# Altium schematic sheet Load.SchDoc(Load)
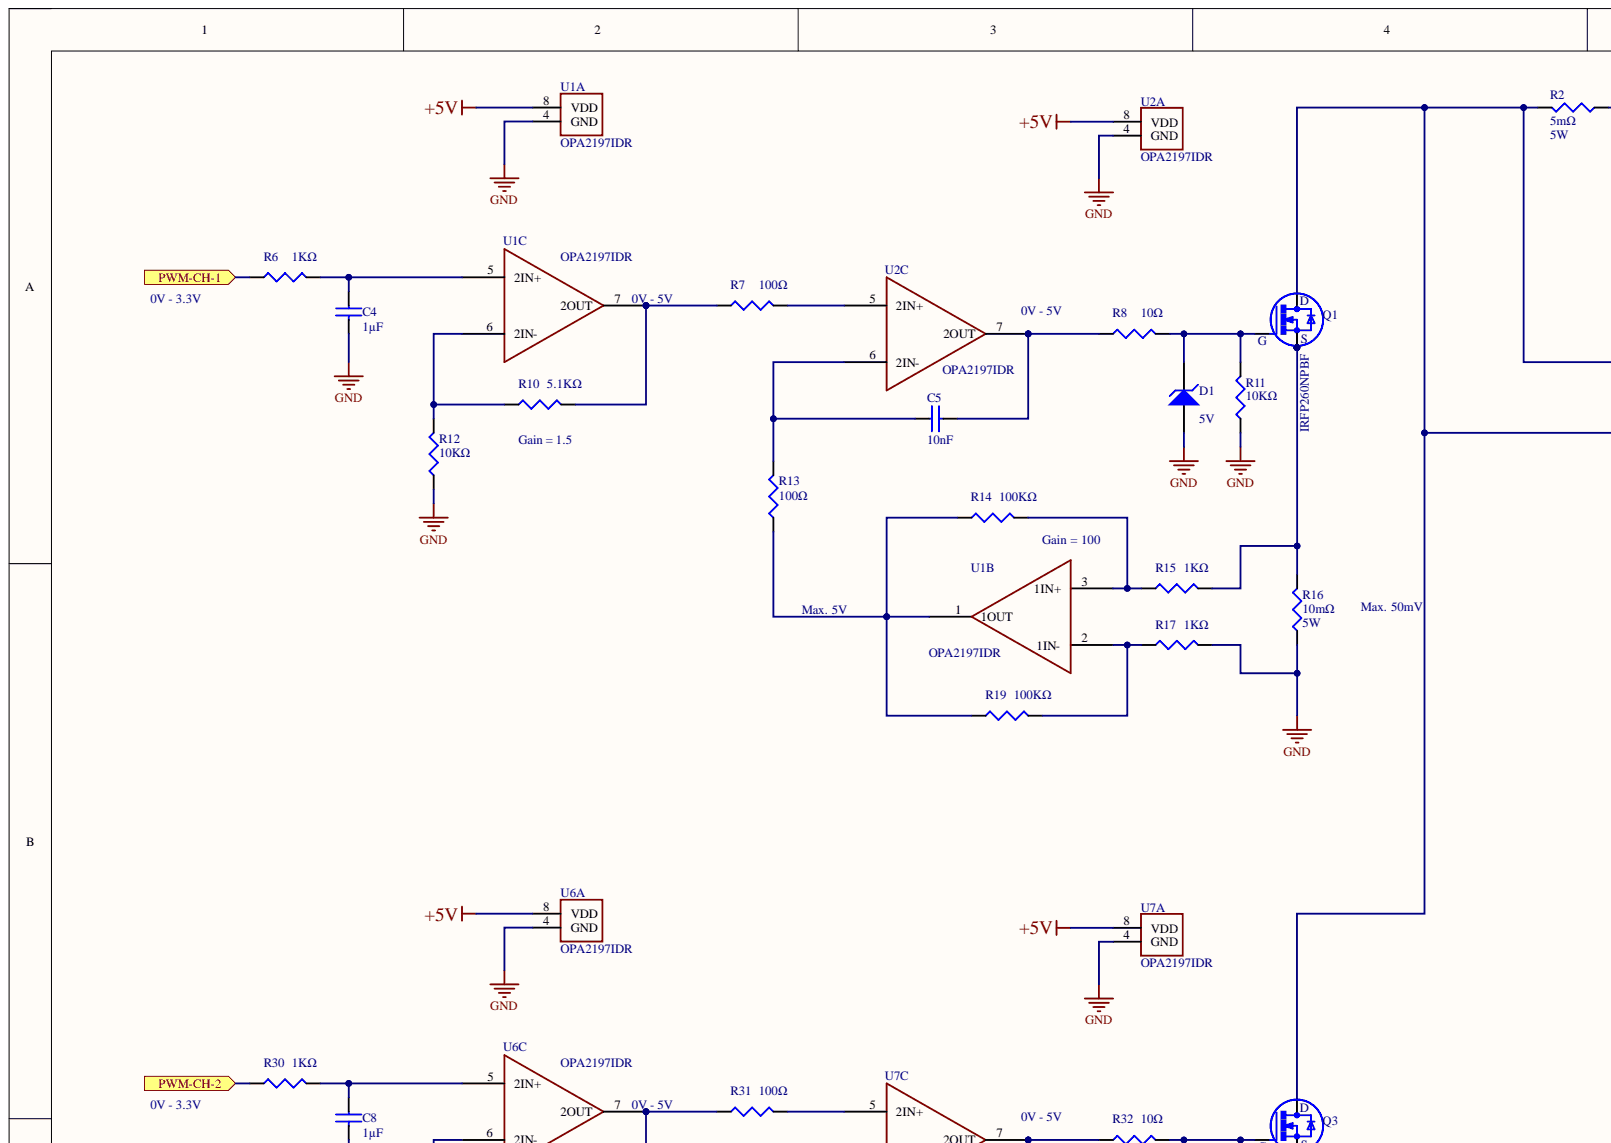
[diagram p0: Load.SchDoc, top left]
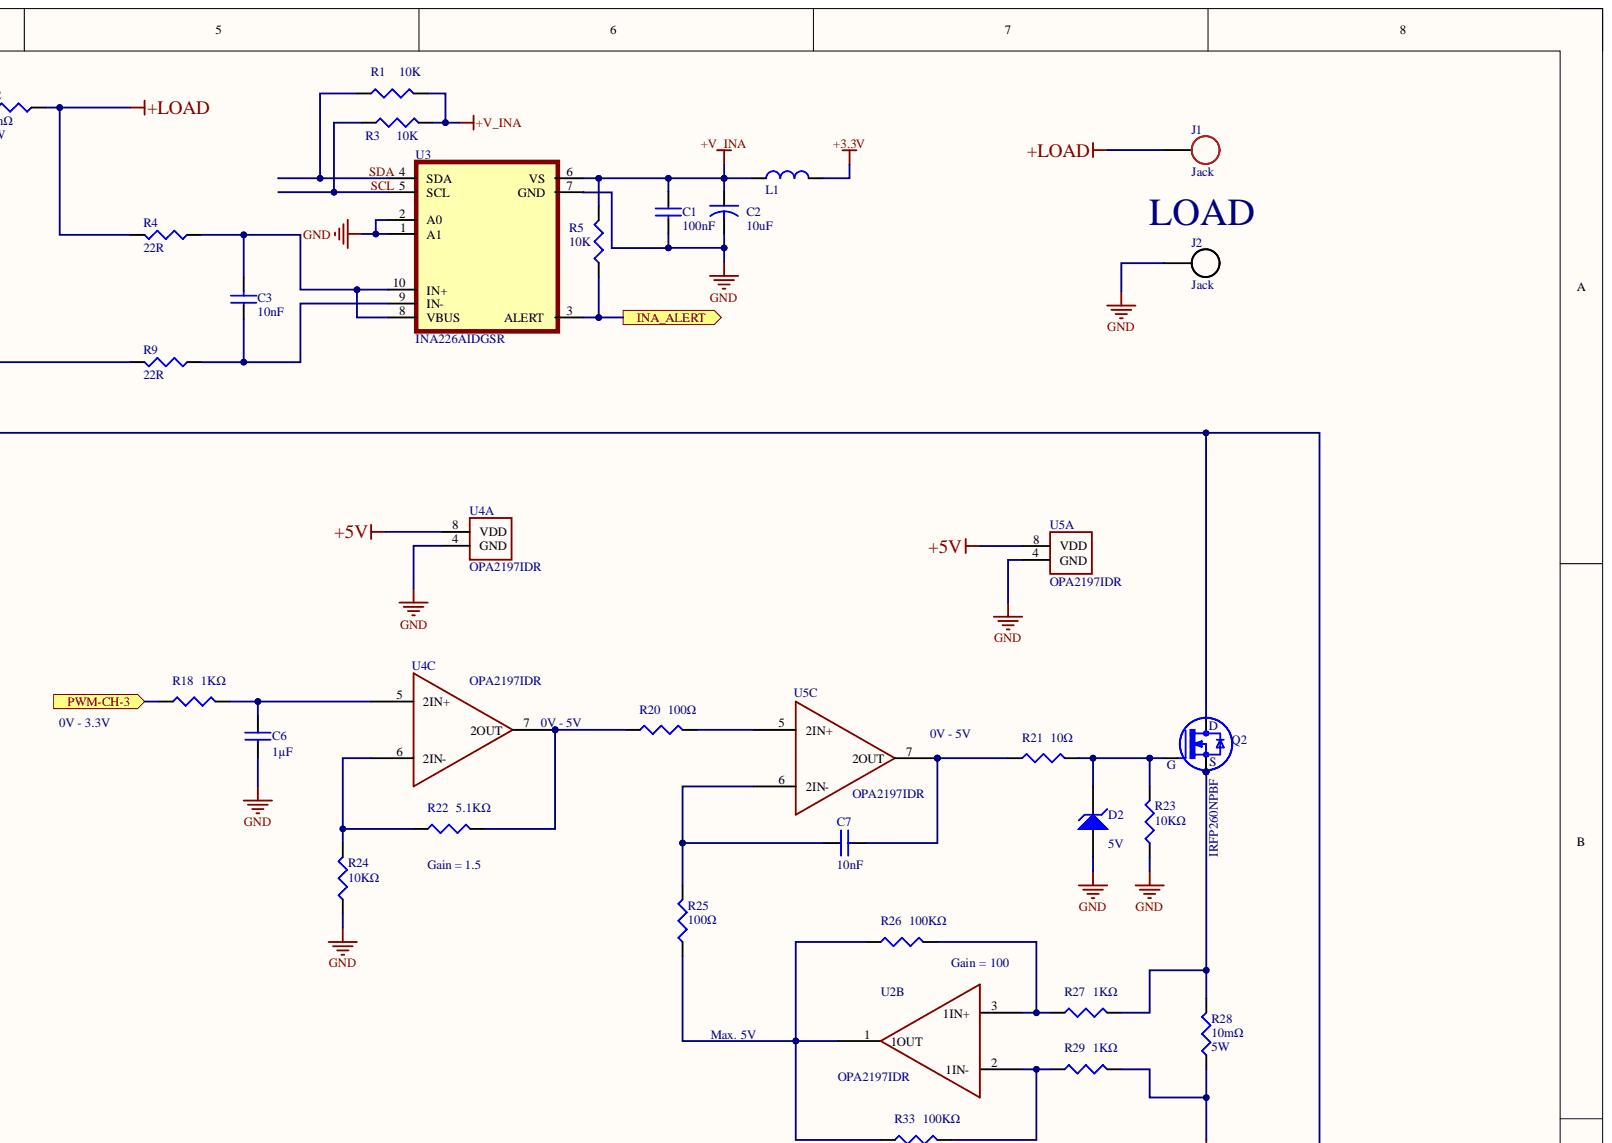
[diagram p1: Load.SchDoc, top right]
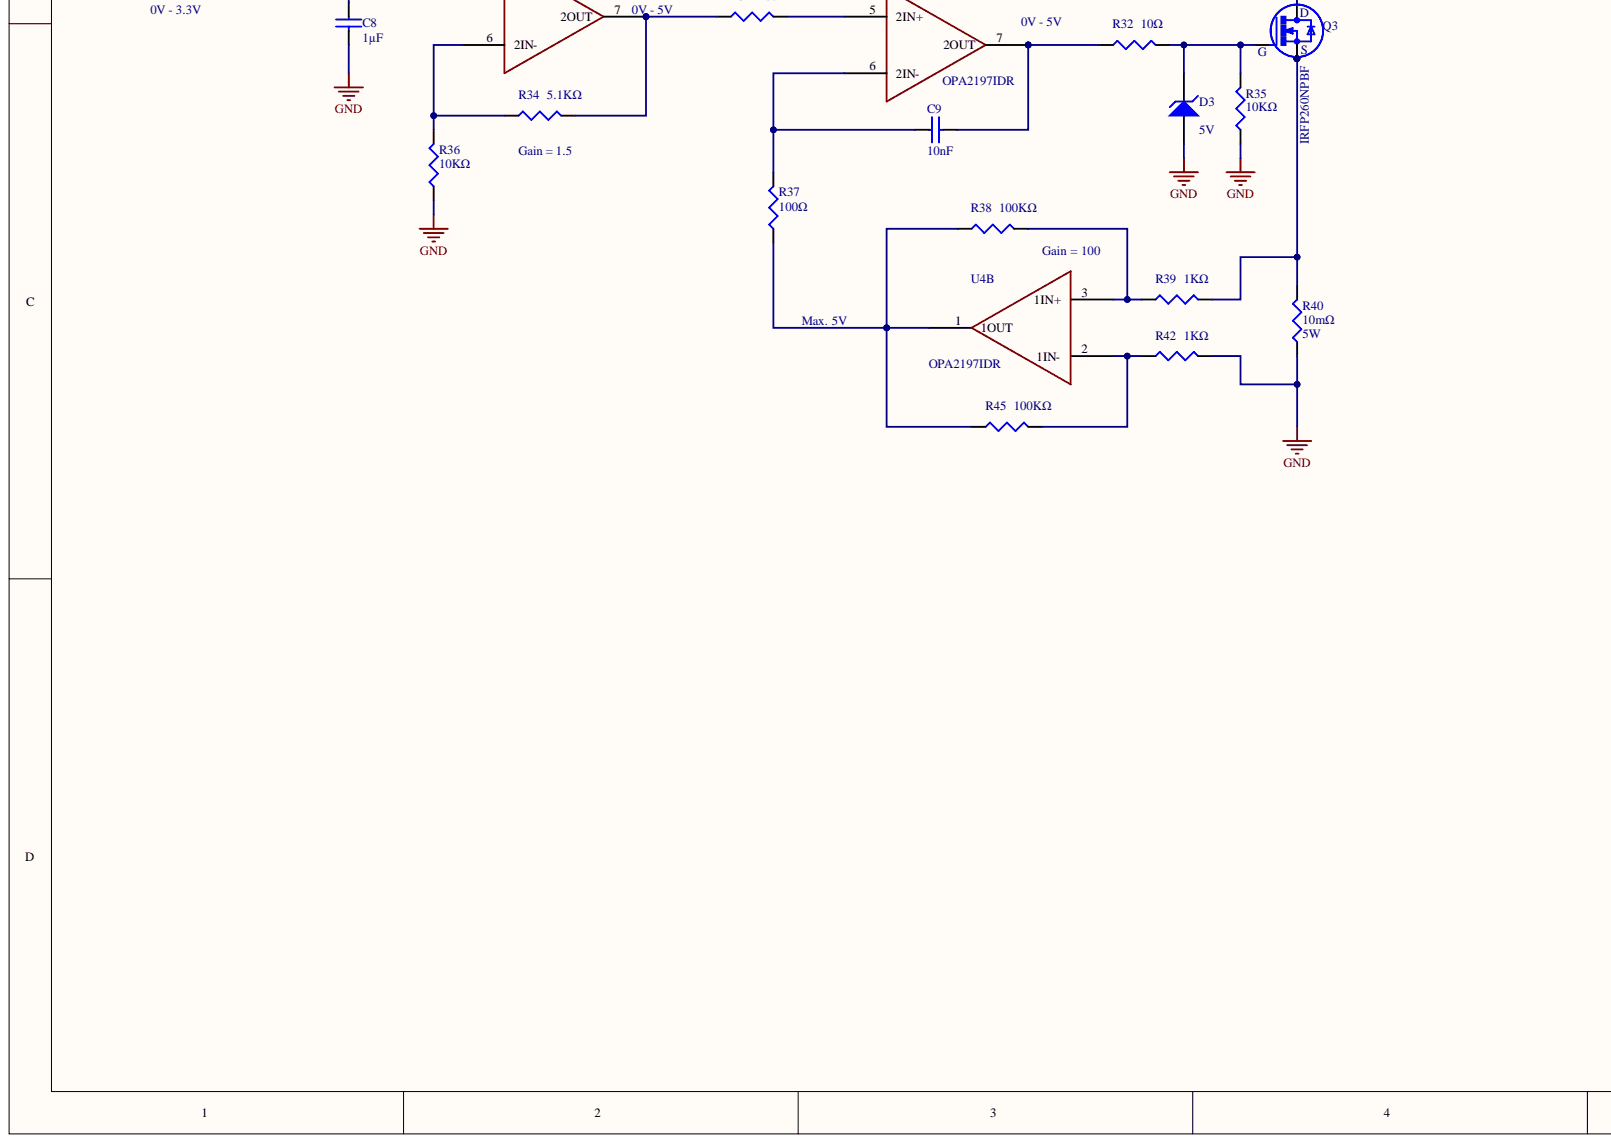
[diagram p2: Load.SchDoc, bottom left]
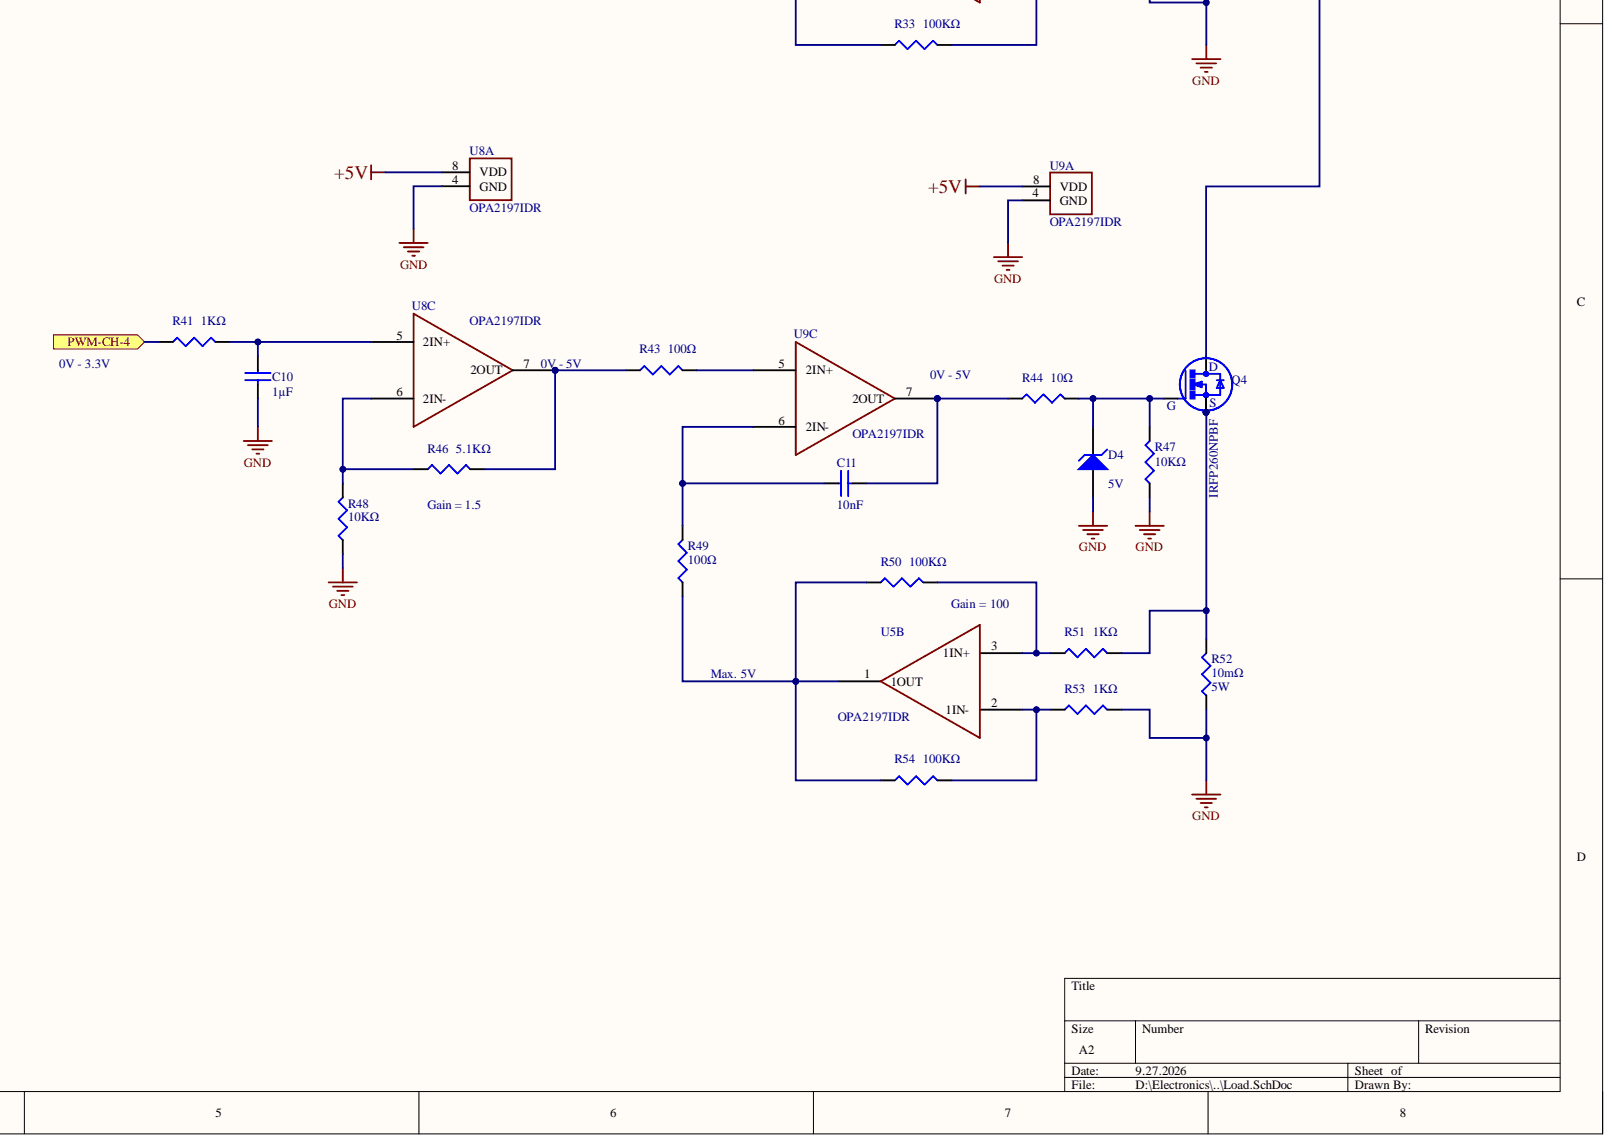
[diagram p3: Load.SchDoc, bottom right]

## Parts
C1  value 100nF  [0805 SMD Ceramic Capacitor]  (hidden comment: C0805C104)
C2  value 10uF  [A Case]  (hidden comment: T491A106)
C3  value 10nF  [0805 SMD Ceramic Capacitor]  (hidden comment: C0805C103)
C4  value 1µF  [0805 SMD Ceramic Capacitor]  (hidden comment: C0805C103)
C5  value 10nF  [0805 SMD Ceramic Capacitor]  (hidden comment: C0805C103)
C6  value 1µF  [0805 SMD Ceramic Capacitor]  (hidden comment: C0805C103)
C7  value 10nF  [0805 SMD Ceramic Capacitor]  (hidden comment: C0805C103)
C8  value 1µF  [0805 SMD Ceramic Capacitor]  (hidden comment: C0805C103)
C9  value 10nF  [0805 SMD Ceramic Capacitor]  (hidden comment: C0805C103)
C10  value 1µF  [0805 SMD Ceramic Capacitor]  (hidden comment: C0805C103)
C11  value 10nF  [0805 SMD Ceramic Capacitor]  (hidden comment: C0805C103)
D1  Voltage: 5V  [SOD80C]  (hidden comment: ZMM55C15-HT)
D2  Voltage: 5V  [SOD80C]  (hidden comment: ZMM55C15-HT)
D3  Voltage: 5V  [SOD80C]  (hidden comment: ZMM55C15-HT)
D4  Voltage: 5V  [SOD80C]  (hidden comment: ZMM55C15-HT)
J1  value Jack  [Red_Banana_Jack]  (hidden comment: RED_Banana_Jack)
J2  value Jack  [Black_Banana_Jack]  (hidden comment: BLACK_Banana_Jack)
L1  0805Ferrite  [0805 Inductor]  (value not drawn)
Q1  IRFP260NPBF  [TO-247AC_H]
Q2  IRFP260NPBF  [TO-247AC_H]
Q3  IRFP260NPBF  [TO-247AC_H]
Q4  IRFP260NPBF  [TO-247AC_H]
R1  value 10K  [0805 Resistor]  (hidden comment: 0805_10K)
R2  value 5mΩ  Power: 5W  [2512 RESISTOR]  (hidden comment: 2512_1mR%1)
R3  value 10K  [0805 Resistor]  (hidden comment: 0805_10K)
R4  value 22R  [0805 Resistor]  (hidden comment: 0805_22R%1)
R5  value 10K  [0805 Resistor]  (hidden comment: 0805_10K)
R6  value 1KΩ  [0805 Resistor]  (hidden comment: 0805)
R7  value 100Ω  [0805 Resistor]  (hidden comment: 0805)
R8  value 10Ω  [0805 Resistor]  (hidden comment: 0805)
R9  value 22R  [0805 Resistor]  (hidden comment: 0805_22R%1)
R10  value 5.1KΩ  [0805 Resistor]  (hidden comment: 0805)
R11  value 10KΩ  [0805 Resistor]  (hidden comment: 0805)
R12  value 10KΩ  [0805 Resistor]  (hidden comment: 0805)
R13  value 100Ω  [0805 Resistor]  (hidden comment: 0805)
R14  value 100KΩ  [0805 Resistor]  (hidden comment: 0805)
R15  value 1KΩ  [0805 Resistor]  (hidden comment: 0805)
R16  value 10mΩ  Power: 5W  [2512 RESISTOR]  (hidden comment: 2512_1mR%1)
R17  value 1KΩ  [0805 Resistor]  (hidden comment: 0805)
R18  value 1KΩ  [0805 Resistor]  (hidden comment: 0805)
R19  value 100KΩ  [0805 Resistor]  (hidden comment: 0805)
R20  value 100Ω  [0805 Resistor]  (hidden comment: 0805)
R21  value 10Ω  [0805 Resistor]  (hidden comment: 0805)
R22  value 5.1KΩ  [0805 Resistor]  (hidden comment: 0805)
R23  value 10KΩ  [0805 Resistor]  (hidden comment: 0805)
R24  value 10KΩ  [0805 Resistor]  (hidden comment: 0805)
R25  value 100Ω  [0805 Resistor]  (hidden comment: 0805)
R26  value 100KΩ  [0805 Resistor]  (hidden comment: 0805)
R27  value 1KΩ  [0805 Resistor]  (hidden comment: 0805)
R28  value 10mΩ  Power: 5W  [2512 RESISTOR]  (hidden comment: 2512_1mR%1)
R29  value 1KΩ  [0805 Resistor]  (hidden comment: 0805)
R30  value 1KΩ  [0805 Resistor]  (hidden comment: 0805)
R31  value 100Ω  [0805 Resistor]  (hidden comment: 0805)
R32  value 10Ω  [0805 Resistor]  (hidden comment: 0805)
R33  value 100KΩ  [0805 Resistor]  (hidden comment: 0805)
R34  value 5.1KΩ  [0805 Resistor]  (hidden comment: 0805)
R35  value 10KΩ  [0805 Resistor]  (hidden comment: 0805)
R36  value 10KΩ  [0805 Resistor]  (hidden comment: 0805)
R37  value 100Ω  [0805 Resistor]  (hidden comment: 0805)
R38  value 100KΩ  [0805 Resistor]  (hidden comment: 0805)
R39  value 1KΩ  [0805 Resistor]  (hidden comment: 0805)
R40  value 10mΩ  Power: 5W  [2512 RESISTOR]  (hidden comment: 2512_1mR%1)
R41  value 1KΩ  [0805 Resistor]  (hidden comment: 0805)
R42  value 1KΩ  [0805 Resistor]  (hidden comment: 0805)
R43  value 100Ω  [0805 Resistor]  (hidden comment: 0805)
R44  value 10Ω  [0805 Resistor]  (hidden comment: 0805)
R45  value 100KΩ  [0805 Resistor]  (hidden comment: 0805)
R46  value 5.1KΩ  [0805 Resistor]  (hidden comment: 0805)
R47  value 10KΩ  [0805 Resistor]  (hidden comment: 0805)
R48  value 10KΩ  [0805 Resistor]  (hidden comment: 0805)
R49  value 100Ω  [0805 Resistor]  (hidden comment: 0805)
R50  value 100KΩ  [0805 Resistor]  (hidden comment: 0805)
R51  value 1KΩ  [0805 Resistor]  (hidden comment: 0805)
R52  value 10mΩ  Power: 5W  [2512 RESISTOR]  (hidden comment: 2512_1mR%1)
R53  value 1KΩ  [0805 Resistor]  (hidden comment: 0805)
R54  value 100KΩ  [0805 Resistor]  (hidden comment: 0805)
U1  OPA2197IDR  [SO8]  (parts A,B,C)
U2  OPA2197IDR  [SO8]  (parts A,B,C)
U3  INA226AIDGSR  [SOP50P490X110-10N]
U4  OPA2197IDR  [SO8]  (parts A,B,C)
U5  OPA2197IDR  [SO8]  (parts A,B,C)
U6  OPA2197IDR  [SO8]  (parts A,C)
U7  OPA2197IDR  [SO8]  (parts A,C)
U8  OPA2197IDR  [SO8]  (parts A,C)
U9  OPA2197IDR  [SO8]  (parts A,C)

## Ports
INA_ALERT, PWM-CH-1, PWM-CH-2, PWM-CH-3, PWM-CH-4

## Nets
+3.3V: L1.2
+5V: U1.8(VDD) U2.8(VDD) U4.8(VDD) U5.8(VDD) U6.8(VDD) U7.8(VDD) U8.8(VDD) U9.8(VDD)
+LOAD: J1.1 R2.1 R4.2
+V_INA: C1.1 C2.1 L1.1 R1.2 R3.2 R5.2 U3.6(VS)
GND: C1.2 C2.2 C4.2 C6.2 C8.2 C10.2 D1.2 D2.2 D3.2 D4.2 J2.1 R11.1 R12.1 R16.1 R17.2 R23.1 R24.1 R28.1 R29.2 R35.1 R36.1 R40.1 R42.2 R47.1 R48.1 R52.1 R53.2 U1.4(GND) U2.4(GND) U3.1(A1) U3.2(A0) U3.7(GND) U4.4(GND) U5.4(GND) U6.4(GND) U7.4(GND) U8.4(GND) U9.4(GND)
INA_ALERT: R5.1 U3.3(ALERT) ; port INA_ALERT
PWM-CH-1: R6.1 ; port PWM-CH-1
PWM-CH-2: R30.1 ; port PWM-CH-2
PWM-CH-3: R18.1 ; port PWM-CH-3
PWM-CH-4: R41.1 ; port PWM-CH-4
SDA: R1.1 U3.4(SDA)
NetC3_1: C3.1 R9.1 U3.9(IN-)
NetC3_2: C3.2 R4.1 U3.8(VBUS) U3.10(IN+)
NetC4_1: C4.1 R6.2 U1.5(2IN+)
NetC5_1: C5.1 R13.2 U2.6(2IN-)
NetC5_2: C5.2 R8.1 U2.7(2OUT)
NetC6_1: C6.1 R18.2 U4.5(2IN+)
NetC7_1: C7.1 R25.2 U5.6(2IN-)
NetC7_2: C7.2 R21.1 U5.7(2OUT)
NetC8_1: C8.1 R30.2 U6.5(2IN+)
NetC9_1: C9.1 R37.2 U7.6(2IN-)
NetC9_2: C9.2 R32.1 U7.7(2OUT)
NetC10_1: C10.1 R41.2 U8.5(2IN+)
NetC11_1: C11.1 R49.2 U9.6(2IN-)
NetC11_2: C11.2 R44.1 U9.7(2OUT)
NetD1_1: D1.1 Q1.1 R8.2 R11.2
NetD2_1: D2.1 Q2.1 R21.2 R23.2
NetD3_1: D3.1 Q3.1 R32.2 R35.2
NetD4_1: D4.1 Q4.1 R44.2 R47.2
NetQ1_1: Q1.1 Q1.2 Q1.3 R15.2 R16.2
NetQ1_2: Q1.2 Q2.2 Q3.2 Q4.2 R2.2 R9.2
NetQ2_1: Q2.1 Q2.2 Q2.3 R27.2 R28.2
NetQ3_1: Q3.1 Q3.2 Q3.3 R39.2 R40.2
NetQ4_1: Q4.1 Q4.2 Q4.3 R51.2 R52.2
NetR3_1: R3.1 U3.5(SCL)
NetR7_1: R7.1 R10.2 U1.7(2OUT)
NetR7_2: R7.2 U2.5(2IN+)
NetR10_1: R10.1 R12.2 U1.6(2IN-)
NetR13_1: R13.1 R14.1 R19.1 U1.1(1OUT)
NetR14_2: R14.2 R15.1 U1.3(1IN+)
NetR17_1: R17.1 R19.2 U1.2(1IN-)
NetR20_1: R20.1 R22.2 U4.7(2OUT)
NetR20_2: R20.2 U5.5(2IN+)
NetR22_1: R22.1 R24.2 U4.6(2IN-)
NetR25_1: R25.1 R26.1 R33.1 U2.1(1OUT)
NetR26_2: R26.2 R27.1 U2.3(1IN+)
NetR29_1: R29.1 R33.2 U2.2(1IN-)
NetR31_1: R31.1 R34.2 U6.7(2OUT)
NetR31_2: R31.2 U7.5(2IN+)
NetR34_1: R34.1 R36.2 U6.6(2IN-)
NetR37_1: R37.1 R38.1 R45.1 U4.1(1OUT)
NetR38_2: R38.2 R39.1 U4.3(1IN+)
NetR42_1: R42.1 R45.2 U4.2(1IN-)
NetR43_1: R43.1 R46.2 U8.7(2OUT)
NetR43_2: R43.2 U9.5(2IN+)
NetR46_1: R46.1 R48.2 U8.6(2IN-)
NetR49_1: R49.1 R50.1 R54.1 U5.1(1OUT)
NetR50_2: R50.2 R51.1 U5.3(1IN+)
NetR53_1: R53.1 R54.2 U5.2(1IN-)
SCL:

## Library data of the parts
C1 = C0805C104: Manufacturer: KEMET; Manufacturer Code: C0805C104J5RACTU; Voltage: 50V; Component Kind: Standard; Farnell Code: 1414663; Library Name: Capacitors.SchLib; Package: 0805; Unit Price: 0,06 €
C2 = T491A106: Manufacturer: KEMET; Manufacturer Code: T491A106K006AT; Voltage: 6V3; Component Kind: Standard; Farnell Code: 1457412; Library Name: Capacitors.SchLib; Unit Price: 0,143 €
C3 = C0805C103: Manufacturer: KEMET; Manufacturer Code: C0805C103J3GACTU; Temp. Coefficient: ±30ppm/°C; Tolerance: ±5%; Voltage: 25V; Component Kind: Standard; Farnell Code: 1457736; Library Name: Capacitors.SchLib; Package: 0805; Unit Price: 0,64 €
D1-D4 = ZMM55C15-HT: DIODE ZENER 500mW 15V ±5% SOD80 (MINIMELF) SMT; Manufacturer: HOTTECH; Power: 0.5W; Component Kind: Standard; Library Name: ZenerDiode.SchLib; Manufacturer Code: ZMM55C15-HT; Package: SOD80; Unit Price: 0.011; Value: ZMM55C15-HT
L1 = 0805Ferrite: Manufacturer: TDK; Manufacturer Code: MMZ2012R102A; Current: 500mA; Value: 1K; Component Kind: Standard; Farnell Code: 1669719; Library Name: FerriteBead.SchLib; Unit Price: 0,059 €
Q1-Q4 = IRFP260NPBF: N-Channel 200 V 50A (Tc) 300W (Tc) Through Hole TO-247AC; Manufacturer: Infineon Technologies; RDS: 40mΩ @ 28A, 10V; Voltage: 200V; Component Kind: Standard; Current: 50A; Library Name: MOSFET.SchLib; Manufacturer Code: IRFP260NPBF; Package: TO-247AC; Unit Price: 4.24; Value: IRFP260NPBF
R1 R3 R5 = 0805_10K: Manufacturer: MULTICOMP; Manufacturer Code: MC 0.1W 0805 1% 10K; Tolerance: ±1%; Component Kind: Standard; Farnell Code: 9332391; Library Name: Resistors.SchLib; Unit Price: 0,03 €
R2 R16 R28 R40 R52 = 2512_1mR%1: 1 mOhms ±1% 2W Chip Resistor 2512 (6332 Metric) Anti-Sulfur, Current Sense, Flame Retardant Coating, Moisture Resistant, Safety Metal Element; Manufacturer: Thin Film Technology Corp.; Manufacturer Code: D1MPAB2512RR001FA-T4; Temperature Coefficient: ±100ppm/°C; Component Kind: Standard; Digikey Code: 4463-D1MPAB2512RR001FA-T4CT-ND; Library Name: Resistors.SchLib; Package: 0805; Tolerance: ±1%; Unit Price: 0.47
R4 R9 = 0805_22R%1: Manufacturer: MULTICOMP; Manufacturer Code: MC01W0805122R; Tolerance: ±1%; Component Kind: Standard; Farnell Code: 9332847; Library Name: Resistors.SchLib; MOQ: 10; Unit Price: 0,02 €
U1 U2 U4-U9 = OPA2197IDR: Manufacturer: Texas Instruments; Component Kind: Standard; Digikey Code: 296-44769-1-ND; Library Name: Opamp.SchLib; Manufacturer Code: OPA2197IDR; Package: SO-8; Unit Price: 3.19; Value: OPA2197IDR
U3 = INA226AIDGSR: 36V, 16-bit, ultra-precise i2c output current/voltage/power monitor w/alert 10-VSSOP -40 to 125; Manufacturer: Texas Instruments; Component Kind: Standard; Library Name: INA226AIDGSR.SchLib; Manufacturer Code: INA226AIDGSR; Package: VSSOP-10; Price: 0.638 $; Value: INA226AIDGSR
C4-C11 = C0805C103: Component Kind: Standard; Library Name: Capacitors.SchLib
J1 = RED_Banana_Jack: Component Kind: Standard; Library Name: Terminal.SchLib; Unit Price: -
J2 = BLACK_Banana_Jack: Component Kind: Standard; Library Name: Terminal.SchLib; Unit Price: -
R6-R8 R10-R15 R17-R27 R29-R39 R41-R51 R53 R54 = 0805: Component Kind: Standard; Library Name: Resistors.SchLib
